# Altium schematic sheet Connectors.SchDoc(Connectors)
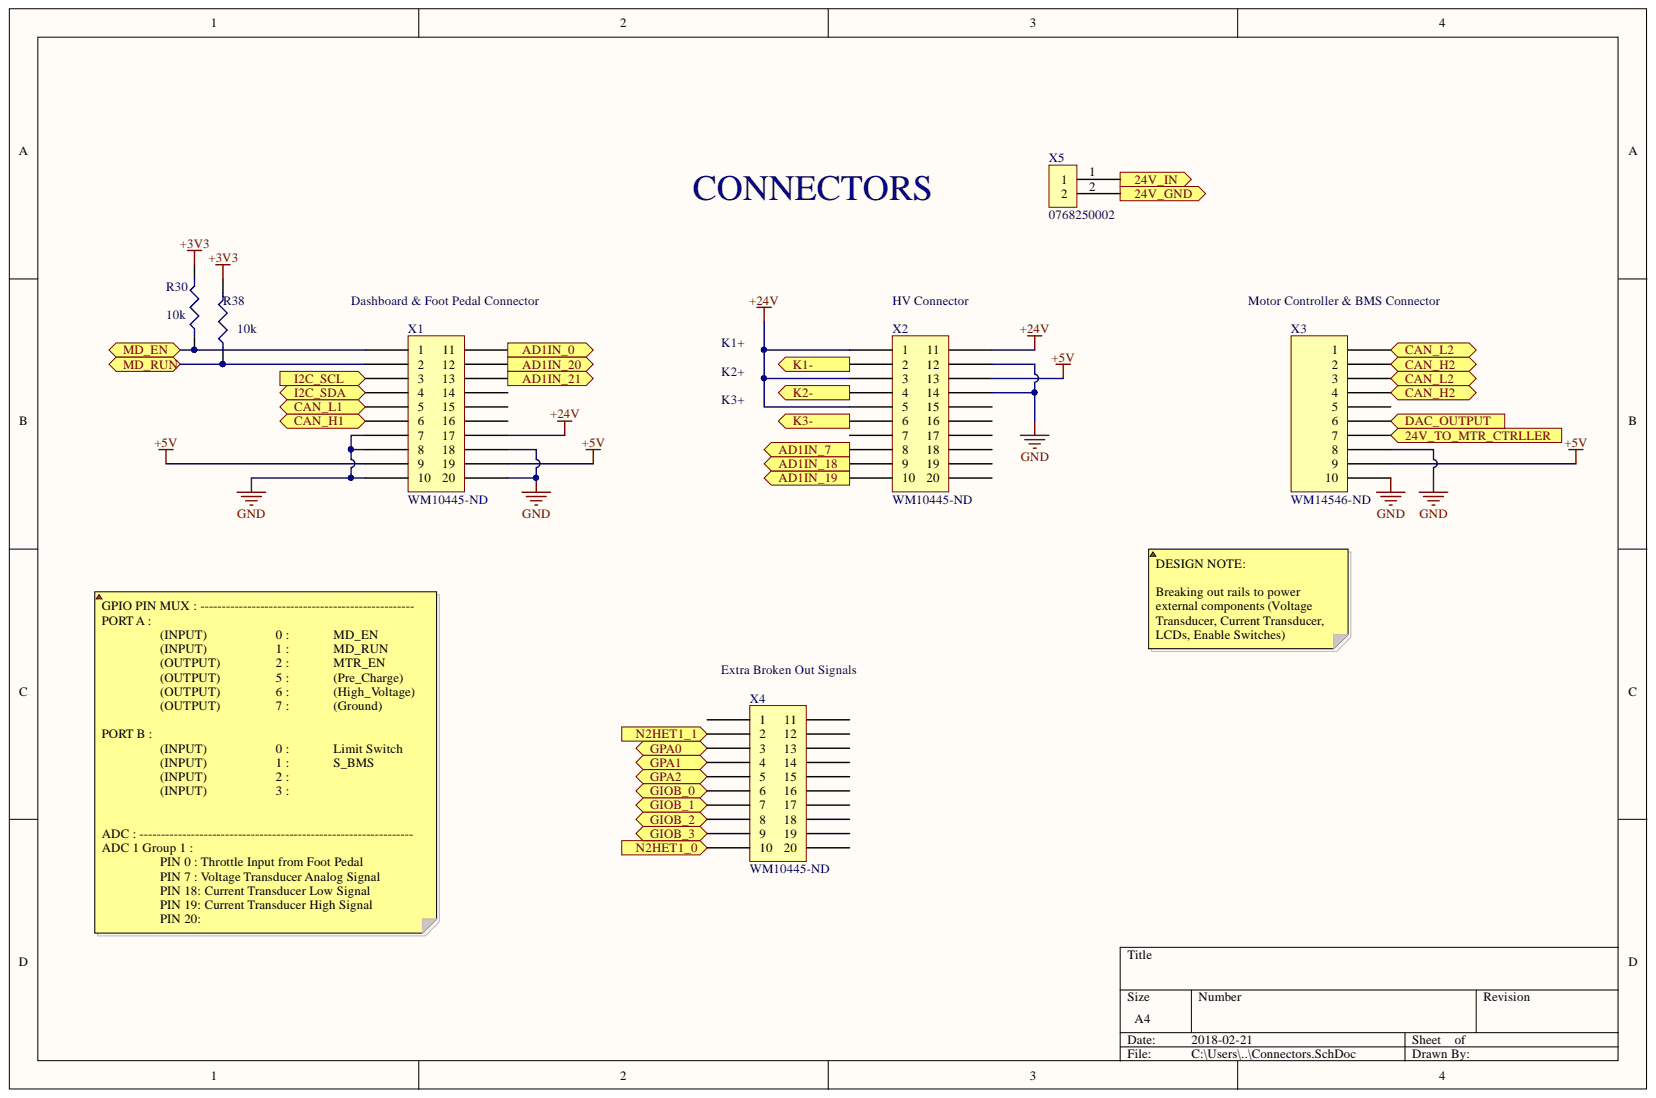
[diagram p0: Connectors.SchDoc]

## Parts
R30  10k  [R0805]
R38  10k  [R0805]
X1  WM10445-ND  [MOLEX_20pin]
X2  WM10445-ND  [MOLEX_20pin]
X3  WM14546-ND  [MOLEX_10PIN]
X4  WM10445-ND  [MOLEX_20pin]
X5  0768250002  [MOLEX_2PIN_MEGAFIT]

## Ports
24V_GND, 24V_IN, 24V_TO_MTR_CTRLLER, AD1IN_0, AD1IN_7, AD1IN_18, AD1IN_19, AD1IN_20, AD1IN_21, CAN_H1, CAN_H2, CAN_L1, CAN_L2, DAC_OUTPUT, GIOB_0, GIOB_1, GIOB_2, GIOB_3, GPA0, GPA1, GPA2, I2C_SCL, I2C_SDA, K1-, K2-, K3-, MD_EN, MD_RUN, N2HET1_0, N2HET1_1

## Nets
+3V3: R30.2 R38.2
+5V: X1.9 X1.19 X2.13 X3.9
+24V: X1.17 X2.1 X2.3 X2.5 X2.11
GND: X1.7 X1.8 X1.10 X1.18 X1.20 X2.12 X2.14 X3.8 X3.10
24V_GND: X5.2 ; port 24V_GND
24V_IN: X5.1 ; port 24V_IN
24V_TO_MTR_CTRLLER: X3.7 ; port 24V_TO_MTR_CTRLLER
AD1IN_0: X1.11 ; port AD1IN_0
AD1IN_7: X2.8 ; port AD1IN_7
AD1IN_18: X2.9 ; port AD1IN_18
AD1IN_19: X2.10 ; port AD1IN_19
AD1IN_20: X1.12 ; port AD1IN_20
AD1IN_21: X1.13 ; port AD1IN_21
CAN_H1: X1.6 ; port CAN_H1
CAN_H2: X3.2 X3.4 ; port CAN_H2
CAN_L1: X1.5 ; port CAN_L1
CAN_L2: X3.1 X3.3 ; port CAN_L2
DAC_OUTPUT: X3.6 ; port DAC_OUTPUT
GIOB_0: X4.6 ; port GIOB_0
GIOB_1: X4.7 ; port GIOB_1
GIOB_2: X4.8 ; port GIOB_2
GIOB_3: X4.9 ; port GIOB_3
GPA0: X4.3 ; port GPA0
GPA1: X4.4 ; port GPA1
GPA2: X4.5 ; port GPA2
I2C_SCL: X1.3 ; port I2C_SCL
I2C_SDA: X1.4 ; port I2C_SDA
K1-: X2.2 ; port K1-
K2-: X2.4 ; port K2-
K3-: X2.6 ; port K3-
MD_EN: R30.1 X1.1 ; port MD_EN
MD_RUN: R38.1 X1.2 ; port MD_RUN
N2HET1_0: X4.10 ; port N2HET1_0
N2HET1_1: X4.2 ; port N2HET1_1

Not connected: X1 14 15 16; X2 7 15 16 17 18 19 20; X3 5; X4 1 11 12 13 14 15 16 17 18 19 20

## Library data of the parts
R30 R38 = RC0805FR-071KL: RES SMD 10K OHM 1% 1/8W 0805; Manufacturer: Yageo Phycomp; Voltage Rating (DC): 150V; Temperature Coefficient: 100ppm/°C; Resistance: 1KR; Power Rating: 125mW; Width: 1.25mm; Tolerance: 1%; Supplier 1: Digi-Key; Stock 1: 5527973; REACH SVHC: No SVHC; Pricing 1: 1=0.1, 10=0.022, 100=0.0088, 1000=0.004, 2500=0.0034 (USD); Packaging: Cut Tape; Number of Pins: 2; Min Operating Temperature: -55°C; Max Operating Temperature: 155°C; Manufacturer Part Number: RC0805FR-071KL; Length: 2mm; Lead Free: Lead Free; Height: 0.5mm; Depth: 1.25mm; Component Kind: Standard; Case/Package: 0805; Library Name: PHANTOM_LIBRARY.SchLib
X1 X2 X4 = 0346916200: CONN_20Positions Molex Stac64 Header, Shrouded Connector 0.100" (2.54mm) Through Hole, Right Angle Tin; Row Spacing: 0.1inch; Number of Positions: 20; Connector Type: Male Pin; Termination: Solder; Supplier Part Number 1: WM10445-ND; Supplier 1: Digi-Key; Stock 1: 334; Pricing 1: 1=3.07, 10=2.946, 100=2.4546, 500=2.0864, 1000=1.7796, 5000=1.5955 (USD); Pitch: 0.1inch; Packaging: Tray; Package Quantity: 560; Number of Rows: 2; Manufacturer Part Number: 0346916200; Manufacturer: Molex; Contact Plating: Tin; ComponentLink1URL: https://datasheet.ciiva.com/29142/987651-0141-29142848.pdf; ComponentLink1Description: https://datasheet.ciiva.com/29142/987651-0141-29142848.pdf; Component Kind: Standard; Colour: Black; Case/Package: 100; Library Name: PHANTOM_LIBRARY.SchLib
X3 = 0346960101: CONN_10Positions Molex Stac64 Thru-Hole Tray; Row Spacing: 0.248inch; Pitch: 2.54mm; Number of Positions: 10; Gender: Male; Connector Type: Male Pin; Termination: Solder; Supplier Part Number 1: WM14546-ND; Supplier 1: Digi-Key; Stock 1: 1144; Pricing 1: 1=2.65, 10=2.54, 100=2.1164, 500=1.7989, 1000=1.5344, 5000=1.3757 (USD); Packaging: Tray; Package Quantity: 560; Orientation: Right Angle; Number of Rows: 2; Number of Contacts: 10; Mount: Through Hole; Min Operating Temperature: -40°C; Max Operating Temperature: 100°C; Manufacturer Part Number: 0346960101; Manufacturer: Molex; Length: 34.04mm; Housing Material: Thermoplastic; Housing Colour: Natural; Fastening Type: Latch; Contact Plating: Tin; Contact Material: Copper; ComponentLink2URL: https://datasheet.ciiva.com/10081/0346960101-pcb-headers-10081642.pdf; ComponentLink2Description: https://datasheet.ciiva.com/10081/0346960101-pcb-headers-10081642.pdf; ComponentLink1URL: https://datasheet.ciiva.com/6844/346960101-sd-6844161.pdf; ComponentLink1Description: https://datasheet.ciiva.com/6844/346960101-sd-6844161.pdf; Component Kind: Standard; Colour: Grey; Library Name: PHANTOM_LIBRARY.SchLib
X5 = 0768250002: CONN_2Position_MEGAFIT Molex Through Hole, Right Angle Tin; Row Spacing: 0.224inch; Number of Positions: 2; Connector Type: Male Pin; Termination: Solder; Supplier Part Number 1: WM11969-ND; Supplier 1: Digi-Key; Stock 1: 2862; Pricing 1: 1=1.08, 10=0.946, 25=0.89, 50=0.853, 100=0.816, 250=0.7418, 500=0.6676, 1000=0.5934, 2500=0.5378 (USD); Packaging: Tray; Number of Rows: 2; Mount: Through Hole; Manufacturer Part Number: 0768250002; Manufacturer: Molex; Fastening Type: Ramp; Contact Plating: Tin; ComponentLink1URL: http://www.molex.com/pdm_docs/ps/PS-76823-100.pdf; ComponentLink1Description: http://www.molex.com/pdm_docs/ps/PS-76823-100.pdf; Component Kind: Standard; Colour: Black; Library Name: PHANTOM_LIBRARY.SchLib
